# Altium schematic sheet Powerboard Rev01.SchDoc("Powerboard Rev01")
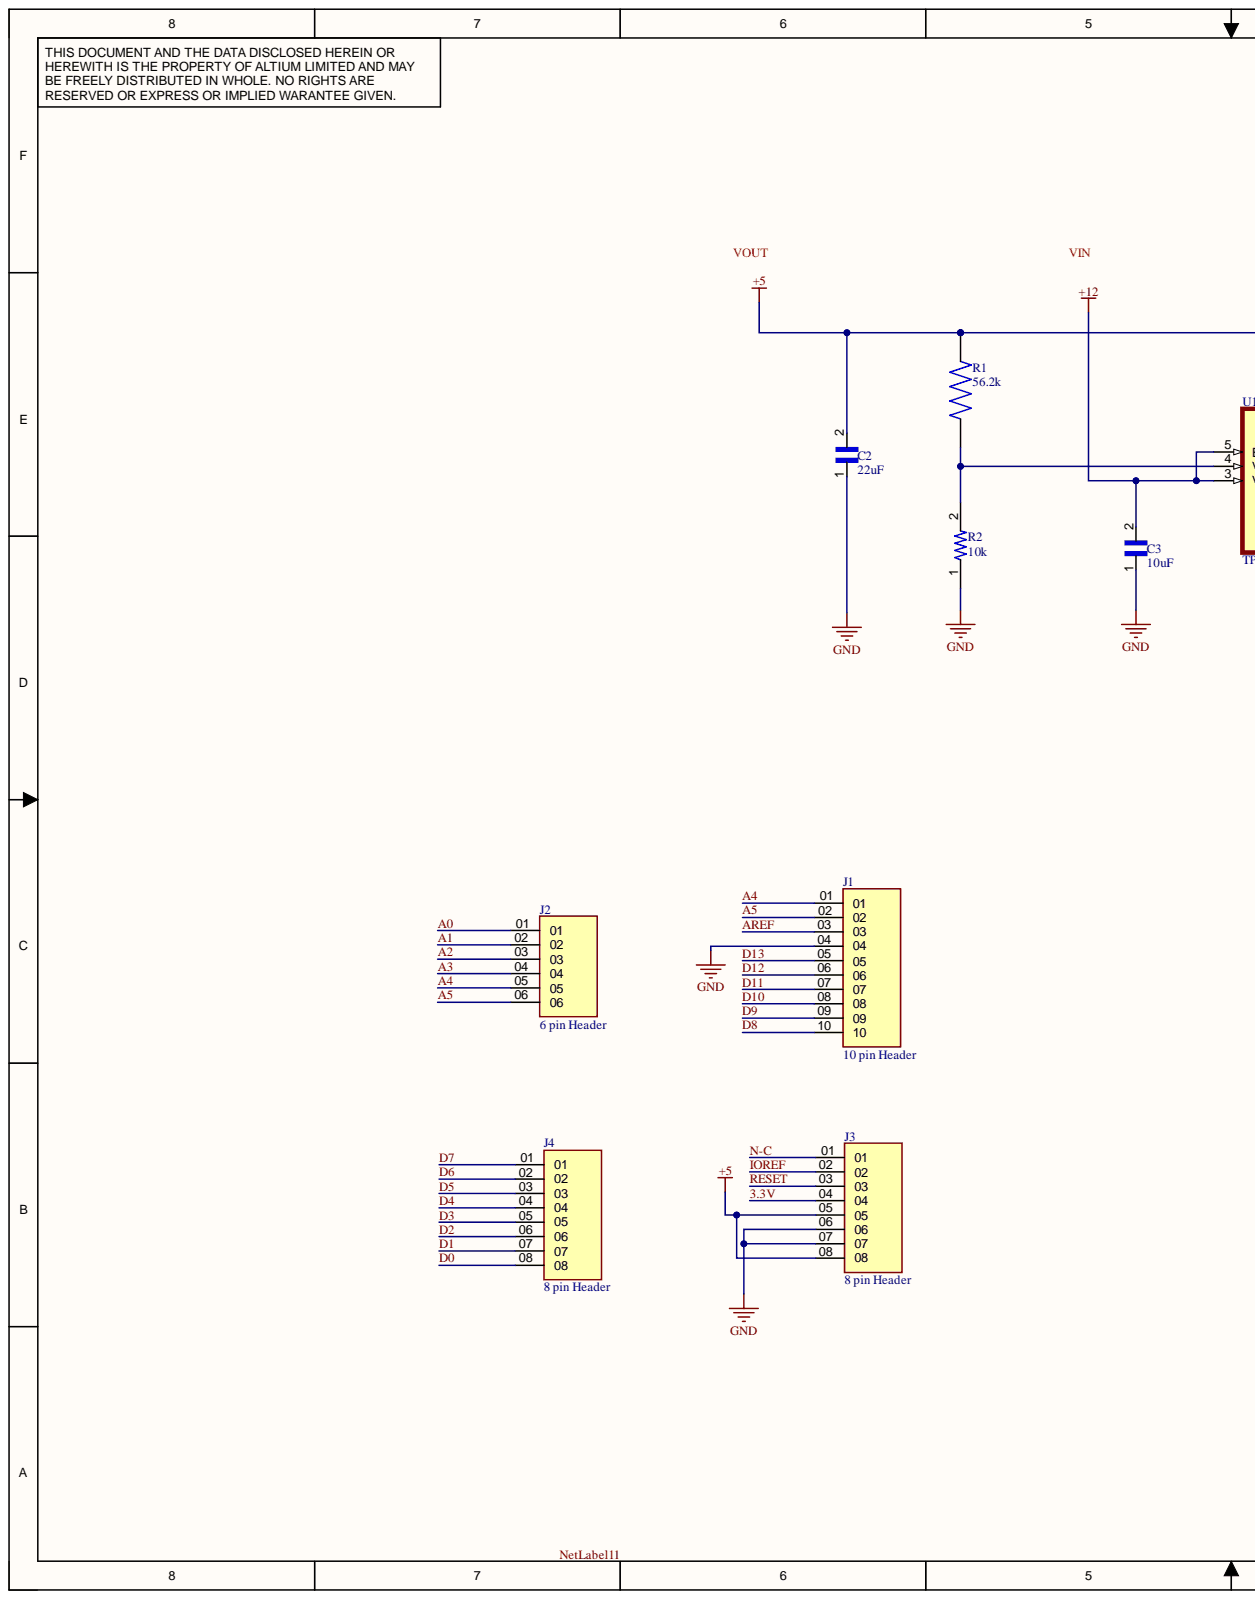
[diagram p0: Powerboard Rev01.SchDoc, left]
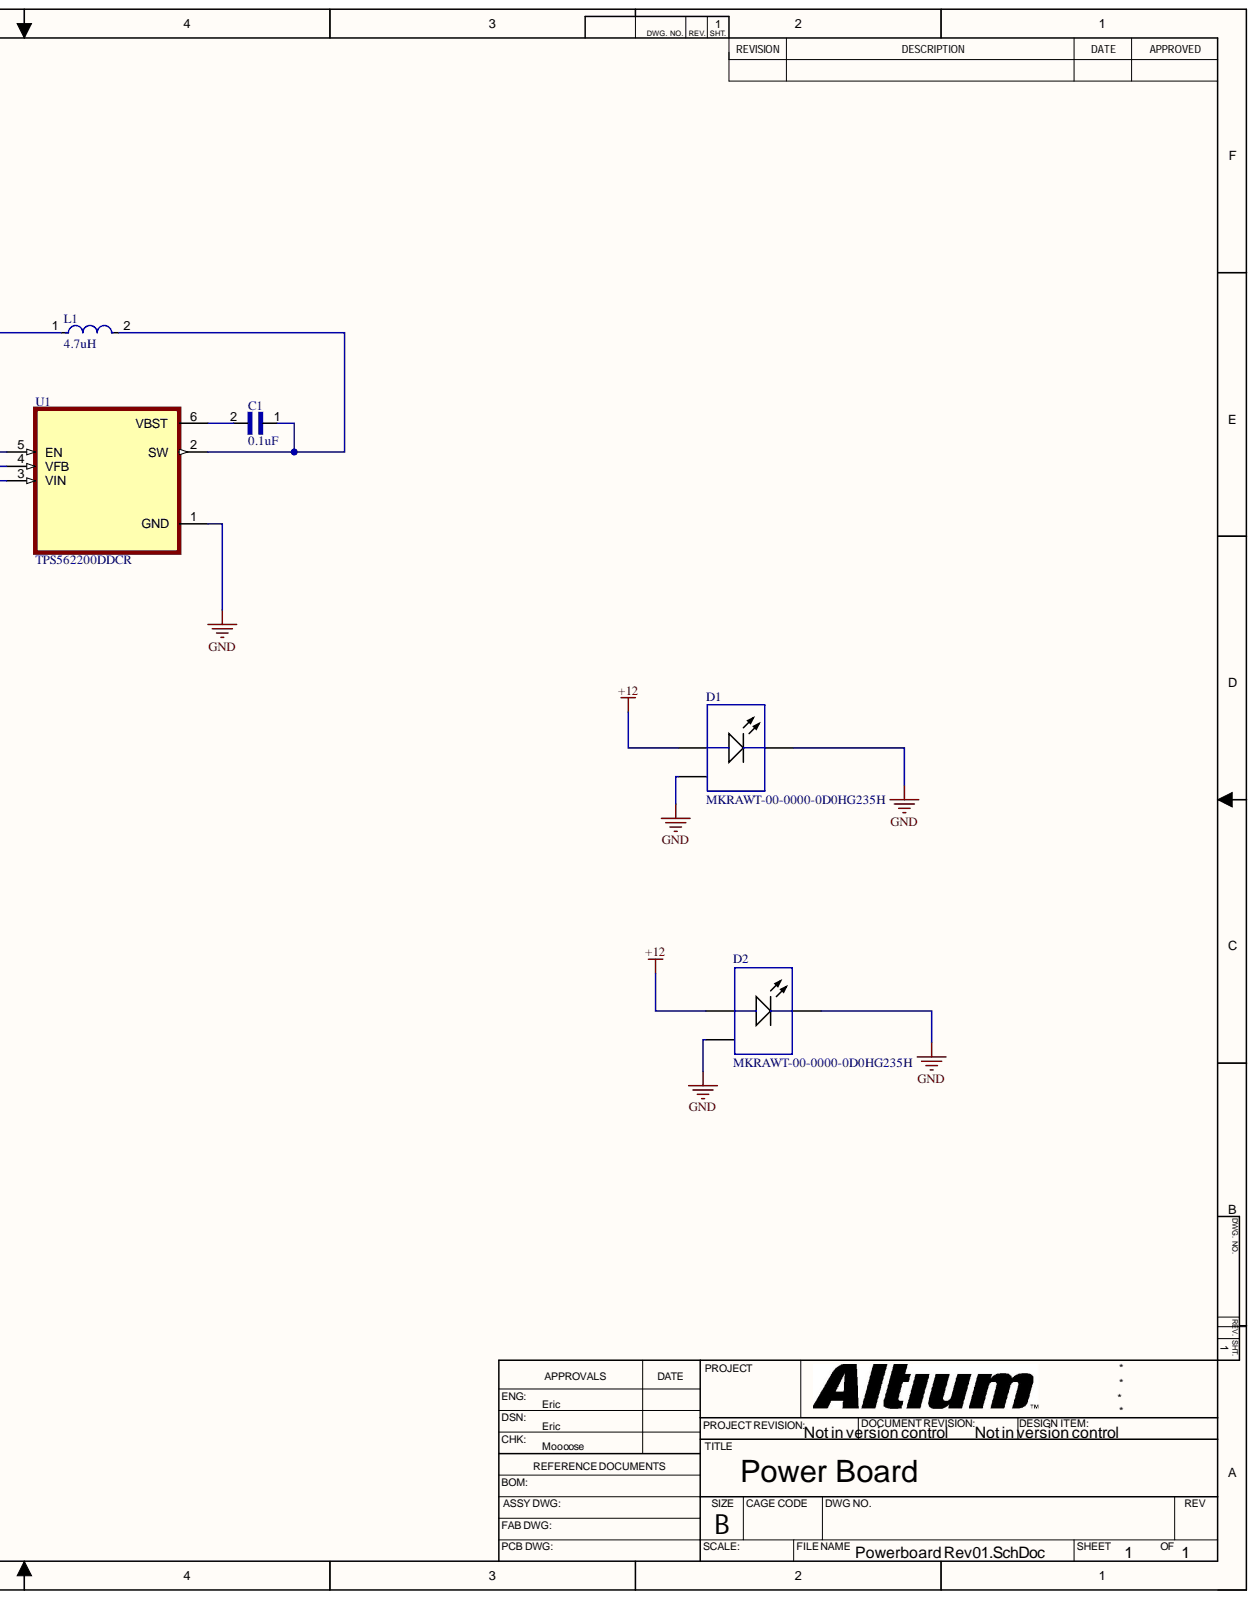
[diagram p1: Powerboard Rev01.SchDoc, right]

## Parts
C1  0.1uF  [CAPC2012X94N]
C2  22uF  [CAPC1608X100N]
C3  10uF  [CAPC2012X100N]
D1  MKRAWT-00-0000-0D0HG235H  [CREE-MK-R-2_V]
D2  MKRAWT-00-0000-0D0HG235H  [CREE-MK-R-2_V]
J1  10 pin Header  [SAMTEC_SSQ-110-03-X-S]
J2  6 pin Header  [SAMTEC_SSQ-106-03-X-S]
J3  8 pin Header  [SAMTEC_SSQ-108-03-X-S]
J4  8 pin Header  [SAMTEC_SSQ-108-03-X-S]
L1  4.7uH  [FP-NR5040-H_4_1-MFG]
R1  56.2k  [RESC0603X26N]
R2  10k  [RESC0603X26N]
U1  TPS562200DDCR  [SOT95P280X110-6N]

## Nets
+5: C2.2 J3.05 J3.08 L1.1 R1.2
+12: C3.2 D1.1 D2.1 U1.3(VIN) U1.5(EN)
GND: C2.1 C3.1 D1.2 D1.3 D2.2 D2.3 J1.04 J3.06 J3.07 R2.1 U1.1(GND)
3.3V: J3.04
A0: J2.01
A1: J2.02
A2: J2.03
A3: J2.04
A4: J1.01 J2.05
A5: J1.02 J2.06
AREF: J1.03
D0: J4.08
D1: J4.07
D2: J4.06
D3: J4.05
D4: J4.04
D5: J4.03
D6: J4.02
D7: J4.01
D8: J1.10
D9: J1.09
D10: J1.08
D11: J1.07
D12: J1.06
D13: J1.05
IOREF: J3.02
N-C: J3.01
RESET: J3.03
NetC1_1: C1.1 L1.2 U1.2(SW)
NetC1_2: C1.2 U1.6(VBST)
NetR1_1: R1.1 R2.2 U1.4(VFB)
NetLabel11:

## Library data of the parts
C1 = 08053C104KAT2A: 08053C104KAT2A Cap Ceramic 0.1uF 25V X7R 10% Pad SMD 0805 125ÂÂŽ T/R; MF: {'manufacturer': u'AVX Interconnect / Elco'}; Availability: Unavailable; Component Kind: Standard; MP: 08053C104KAT2A; Package: None; Price: None
C2 = C1608X5R1A226M080AC: C1608X5R1A226M080AC 22µF ±20% 10V Ceramic Capacitor X5R 0603 (1608 Metric); MF: {'manufacturer': u'TDK'}; Availability: Unavailable; Component Kind: Standard; MP: C1608X5R1A226M080AC; Package: None; Price: None
C3 = C2012X5R1V106K085AC: C2012X5R1V106K085AC 10µF ±10% 35V Ceramic Capacitor X5R 0805 (2012 Metric); MF: {'manufacturer': u'TDK'}; Availability: Unavailable; Component Kind: Standard; MP: C2012X5R1V106K085AC; Package: None; Price: None
D1 D2 = CMP-2100-00977-1: LED, White, 12 V, 2-Pin SMD, RoHS, Tape and Reel; Component Kind: Standard; Library Name: Altium Content Vault
J1 = SSQ-110-03-X-S: SSQ-110-03-X-S 10 Position Receptacle Connector Through Hole; MF: {'manufacturer': u'Samtec'}; Availability: Unavailable; Component Kind: Standard; MP: SSQ-110-03-X-S; Package: None; Price: None
J2 = SSQ-106-03-X-S: SSQ-106-03-X-S 6 Position Receptacle Connector Through Hole; MF: {'manufacturer': u'Samtec Inc.'}; Availability: Unavailable; Component Kind: Standard; MP: SSQ-106-03-X-S; Package: None; Price: None
J3 J4 = SSQ-108-03-X-S: MF: {'manufacturer': u'Samtec'}; Availability: Unavailable; Component Kind: Standard; Description: SSQ-108-03-X-S; MP: SSQ-108-03-X-S; Package: None; Price: None
L1 = CMP-14484-001014-1: NR5040T4R7N SMD Power Inductors 4.7uH ±30% 3.1A 37.7mΩ; Core Material: Ferrite; DC Resistance (DCR): 37.7mR; Max DC Current: 3.3A; Self Resonant Frequency: 28MHz; Shielding: Shielded; Test Frequency: 100kHz; Case/Package: Nonstandard; Component Kind: Standard; Current Rating: 3.1A; Depth: 5.1mm; Halogen Free: Halogen Free; Height: 4mm; Height - Seated (Max): 0.161inch; Inductance: 4.7uH; Inductance Tolerance: 30%; Length: 0.193inch; Library Name: Altium Content Vault; Max Operating Temperature: 120°C; Min Operating Temperature: -25°C; Mount: Surface Mount; Number of Pins: 2; Package Quantity: 1500; Packaging: Tape and Reel; Radiation Hardening: No; Resistor Type: Wirewound; RMS Current (Irms): 3.1A; RoHS Compliant: Yes; Saturation Current: 3.3A; Series: NR; Termination: SMD/SMT; Tolerance: 30%; Width: 4.9mm
R1 = RC0201FR-0756K2L: RC0201FR-0756K2L RES SMD 56.2K OHM 1% 1/20W 0201; MF: {'manufacturer': u'Yageo'}; Availability: Unavailable; Component Kind: Standard; MP: RC0201FR-0756K2L; Package: None; Price: None
R2 = RC0201FR-0710KL: RC0201FR-0710KL  10.0K 1% 0.05W TK200; MF: {'manufacturer': u'Yageo'}; Availability: Unavailable; Component Kind: Standard; MP: RC0201FR-0710KL; Package: None; Price: None
U1 = TPS562200DDCR: MF: {'manufacturer': u'Texas Instruments'}; Availability: Unavailable; Component Kind: Standard; MP: TPS562200DDCR; Package: None; Price: None
